# Altium schematic sheet Sch_mcu_brd_pwr.SchDoc
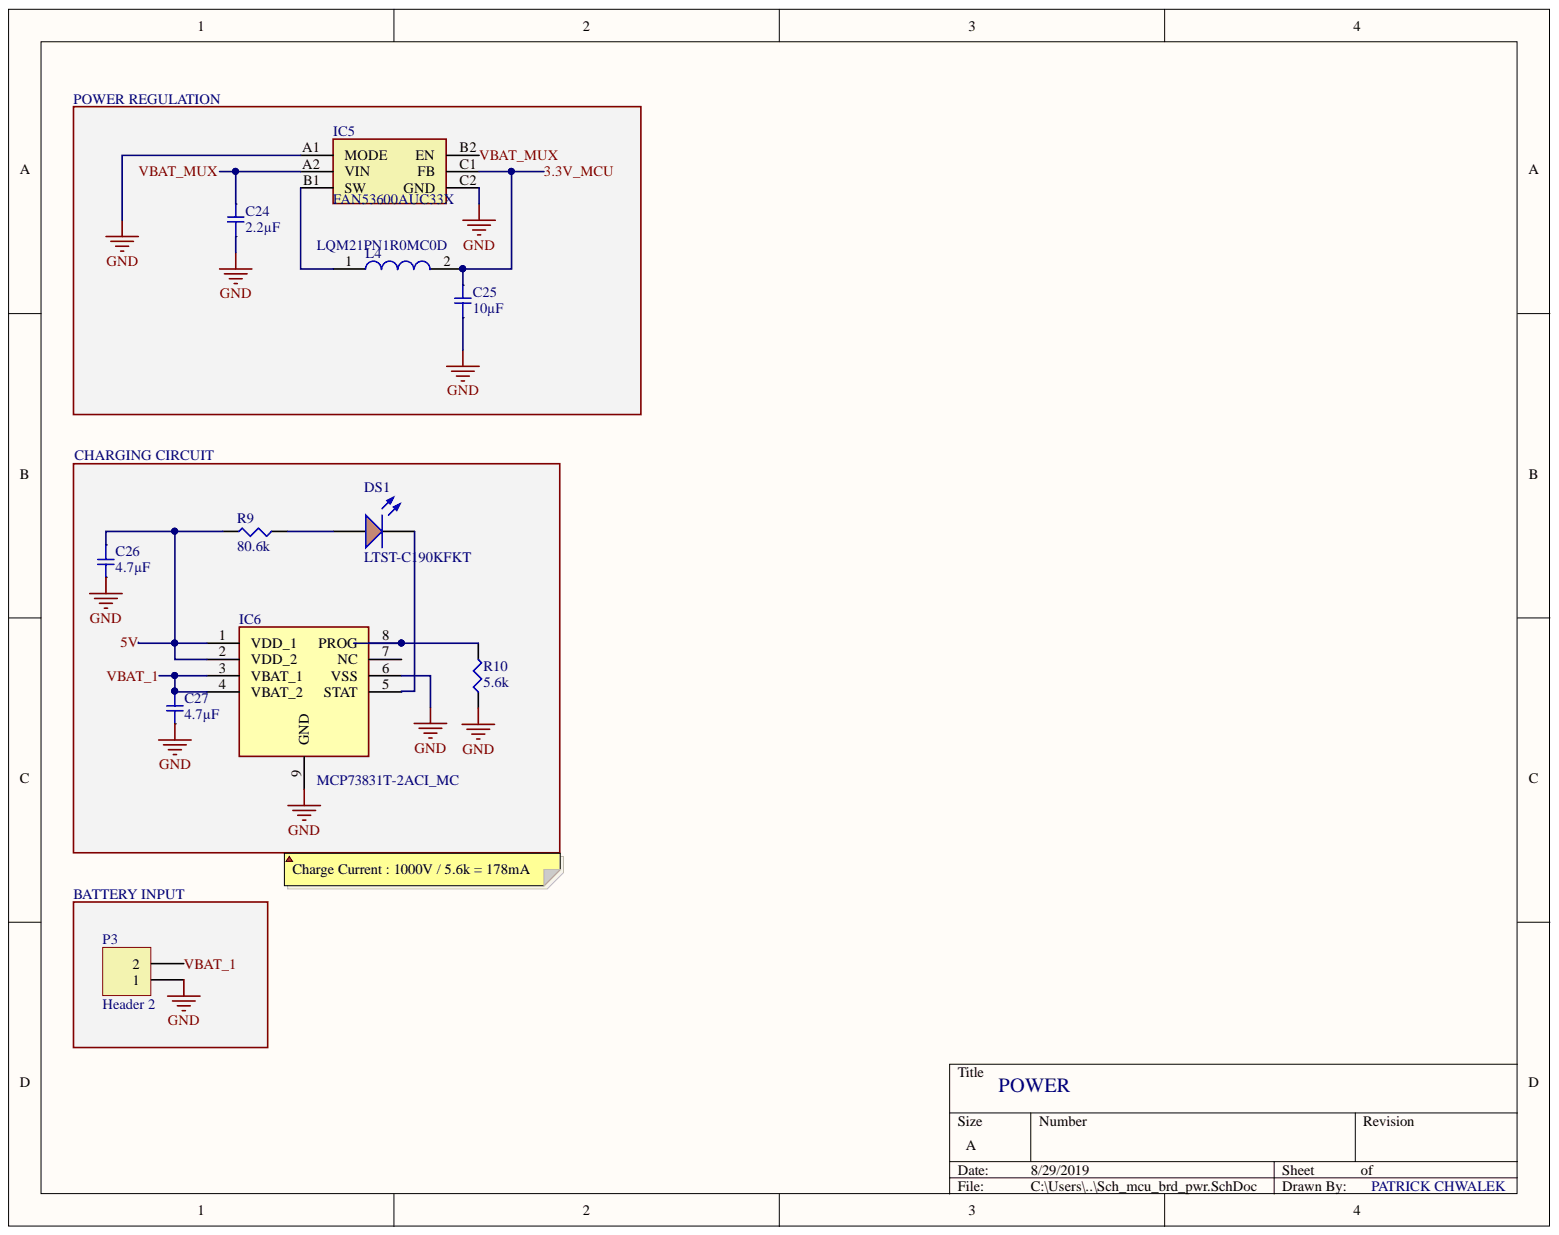
[diagram p0: Sch_mcu_brd_pwr.SchDoc]

## Parts
C24  2.2µF  [SMD-0402C]
C25  10µF  [SMD-0603C]
C26  4.7µF  [SMD-0402C]
C27  4.7µF  [SMD-0402C]
DS1  LTST-C190KFKT  [LTST-C190KFKT_V]
IC5  FAN53600AUC33X  [BGA6C40P2X3_86X116X62]
IC6  MCP73831T-2ACI_MC  [SON50P300X200X100-9N-D]
L4  LQM21PN1R0MC0D  [INDC2012X55N]
P3  Header 2  [HDR1X2]
R9  80.6k  [SMD-0402-RES]
R10  5.6k  [SMD-0402-RES]

## Nets
GND: C24.1 C25.1 C26.2 C27.2 IC5.A1(MODE) IC5.C2(GND) IC6.6(VSS) IC6.9(GND) P3.1 R10.1
3.3V_MCU: C25.2 IC5.C1(FB) L4.2
5V: C26.1 IC6.1(VDD_1) IC6.2(VDD_2) R9.2
VBAT_1: C27.1 IC6.3(VBAT_1) IC6.4(VBAT_2) P3.2
VBAT_MUX: C24.2 IC5.A2(VIN) IC5.B2(EN)
NetDS1_1: DS1.1 R9.1
NetDS1_2: DS1.2 IC6.5(STAT)
NetIC5_B1: IC5.B1(SW) L4.1
NetIC6_8: IC6.8(PROG) R10.2

Not connected: IC6 7(NC)

## Library data of the parts
C24 = GRM155R60J225ME15D: CAP CER 2.2UF 6.3V X5R 0402; Manufacturer: Murata Electronics North America; @ qty: 0; Minimum Quantity: 1; Tolerance: ±20%; Unit Price (USD): 0.15; Applications: General Purpose; Component Kind: Standard; Datasheets: http://www.murata.com/~/media/webrenewal/support/library/catalog/products/capacitor/mlcc/c02e.pdf; Digi-Key Part Number: 490-4519-1-ND; Factory Stock: 0; Features: Derating Recommended; Height - Seated (Max): -; Image: //media.digikey.com/Renders/Murata%20Renders/ZRB-SERIES-0402.jpg; Lead Spacing: -; Lead Style: -; Library Name: capacitor_murata_0402.IntLib; Mounting Type: Surface Mount, MLCC; Operating Temperature: -55°C ~ 85°C; Package / Case: 0402 (1005 Metric); Packaging: Cut Tape (CT); Part Number: GRM155R60J225ME15D; Part Status: Active; Quantity Available: 911621; Ratings: -; Series: GRM; Size / Dimension: 0.039" L x 0.020" W (1.00mm x 0.50mm); Temperature Coefficient: X5R; Thickness (Max): 0.022" (0.55mm); Value: 2.2µF; Voltage: 6.3V
C25 = GRM188R60J106ME47D: CAP CER 10UF 6.3V X5R 0603; Manufacturer: Murata Electronics North America; @ qty: 0; Minimum Quantity: 1; Tolerance: ±20%; Unit Price (USD): 0.15; Applications: General Purpose; Component Kind: Standard; Datasheets: http://www.murata.com/~/media/webrenewal/support/library/catalog/products/capacitor/mlcc/c02e.pdf; Digi-Key Part Number: 490-3896-1-ND; Factory Stock: 0; Features: -; Height - Seated (Max): -; Image: //media.digikey.com/Renders/Murata%20Renders/ZRB-SERIES-0402.jpg; Lead Spacing: -; Lead Style: -; Library Name: capacitor_murata_0603.IntLib; Mounting Type: Surface Mount, MLCC; Operating Temperature: -55°C ~ 85°C; Package / Case: 0603 (1608 Metric); Packaging: Cut Tape (CT); Part Number: GRM188R60J106ME47D; Part Status: Active; Quantity Available: 1578882; Ratings: -; Series: GRM; Size / Dimension: 0.063" L x 0.031" W (1.60mm x 0.80mm); Temperature Coefficient: X5R; Thickness (Max): 0.035" (0.90mm); Value: 10µF; Voltage: 6.3V
C26 C27 = GRM153R60G475ME15D: CAP CER 4.7UF 4V X5R 0402; Manufacturer: Murata Electronics North America; @ qty: 0; Minimum Quantity: 1; Tolerance: ±20%; Unit Price (USD): 0.27; Applications: General Purpose; Component Kind: Standard; Datasheets: http://psearch.en.murata.com/capacitor/product/GRM153R60G475ME15%23.pdf; Digi-Key Part Number: 490-13231-1-ND; Factory Stock: 0; Features: -; Height - Seated (Max): -; Image: //media.digikey.com/Renders/Murata%20Renders/ZRB-SERIES-0402.jpg; Lead Spacing: -; Lead Style: -; Library Name: capacitor_murata_0402.IntLib; Mounting Type: Surface Mount, MLCC; Operating Temperature: -55°C ~ 85°C; Package / Case: 0402 (1005 Metric); Packaging: Cut Tape (CT); Part Number: GRM153R60G475ME15D; Part Status: Active; Quantity Available: 9100; Ratings: -; Series: GRM; Size / Dimension: 0.039" L x 0.020" W (1.00mm x 0.50mm); Temperature Coefficient: X5R; Thickness (Max): 0.013" (0.33mm); Value: 4.7µF; Voltage: 4V
DS1 = CMP-1674-00008-1: LED Uni-Color Orange, 75 mW, 30 mA, -35 to 85 degC, 2-Pin SMD, RoHS, Tape and Reel; Case\Package: 0603; Power Rating: 100 mW; Resistance: 47 kohm; Tolerance: 1%; Voltage Rating: 50 V; Case Code (Imperial): 0603; Case Code (Metric): 1608; Component Kind: Standard; ComponentLink1Description: Manufacturer URL; ComponentLink1URL: http://www.us.liteon.com/; ComponentLink2Description: Datasheet; ComponentLink2URL: http://optoelectronics.liteon.com/upload/download/DS-22-99-0186/S_110_LTST-C190KFKT.pdf; DatasheetVersion: Rev. I, 09/2011; Height: 0.45 mm; Length: 1.6 mm; Library Name: Altium Content Vault; Max Operating Temperature: 155 degC; Min Operating Temperature: -55 degC; Mount: Surface Mount; PackageDescription: 2-Pin Surface Mount Device, Body 1.6 x 0.8 mm; Package Quantity: 5000; PackageReference: C190KFKT; Packaging: Tape and Reel; Pins: 2; REACH SVHC: No SVHC; RoHSCompliant: true; Voltage Rating (DC): 50 V; Width: 0.8 mm
IC5 = FAN53600AUC33X: Integrated Circuit; Manufacturer_Name: Fairchild Semiconductor; Component Kind: Standard; Datasheet Link: https://www.onsemi.com/pub/Collateral/FAN53610-D.pdf; Height: 0.625mm; Library Name: SamacSys.SchLib; Manufacturer_Part_Number: FAN53600AUC33X; Mouser Part Number: 512-FAN53600AUC33X; Mouser Price/Stock: https://www.mouser.com/Search/Refine.aspx?Keyword=512-FAN53600AUC33X
IC6 = MCP73831T-2ACI_MC: Integrated Circuit; Manufacturer_Name: Microchip; Manufacturer_Part_Number: MCP73831T-2ACI/MC; Allied_Number: 70452042; Allied Price/Stock: https://www.alliedelec.com/microchiptechnologyinc-mcp73831t-2aci-mc/70452042/; Component Kind: Standard; Datasheet Link: http://ww1.microchip.com/downloads/en/DeviceDoc/20001984g.pdf; Height: 1mm; Library Name: SamacSys.SchLib; Mouser Part Number: 579-MCP73831T-2ACIMC; Mouser Price/Stock: https://www.mouser.com/Search/Refine.aspx?Keyword=579-MCP73831T-2ACIMC
L4 = LQM21PN1R0MC0D: Inductor; Manufacturer_Name: Murata Electronics; Component Kind: Standard; Datasheet Link: https://componentsearchengine.com/Datasheets/2/LQM21PN1R0MC0D.pdf; Height: 0.55mm; Library Name: SamacSys.SchLib; Manufacturer_Part_Number: LQM21PN1R0MC0D; Mouser Part Number: 81-LQM21PN1R0MC0D; Mouser Price/Stock: https://www.mouser.com/Search/Refine.aspx?Keyword=81-LQM21PN1R0MC0D; RS Part Number: 7926388; RS Price/Stock: https://uk.rs-online.com/web/p/products/7926388
P3 = Header 2: Header, 2-Pin; Component Kind: Standard; LatestRevisionDate: 17-Jul-2002; LatestRevisionNote: Re-released for DXP Platform.; Library Name: Miscellaneous Connectors.IntLib; Signal Integrity: Connector; Publisher: Altium Limited
R9 = ERJ-2RKF8062X: RES SMD 80.6K OHM 1% 1/10W 0402; Manufacturer: Panasonic Electronic Components; @ qty: 1500; Factory Stock: 0; Minimum Quantity: 1; Power (Watts): 0.1W, 1/10W; Temperature Coefficient: ±100ppm/°C; Unit Price (USD): 0.00496; Component Kind: Standard; Composition: Thick Film; Datasheets: http://industrial.panasonic.com/www-cgi/jvcr13pz.cgi?E+PZ+3+AOA0002+ERJ2RKF8062X+7+WW; Digi-Key Part Number: P80.6KLCT-ND; Failure Rate: -; Features: Automotive AEC-Q200; Height - Seated (Max): 0.016" (0.40mm); Image: //media.digikey.com/Photos/Panasonic%20Photos/ERJ-PA2-Series.jpg; Library Name: Panasonic_ERJ_0402.IntLib; Number of Terminations: 2; Operating Temperature: -55°C ~ 155°C; Package / Case: 0402 (1005 Metric); Packaging: Cut Tape (CT); Part Number: ERJ-2RKF8062X; Part Status: Active; Quantity Available: 91747; Series: ERJ; Size / Dimension: 0.039" L x 0.020" W (1.00mm x 0.50mm); Supplier Device Package: 0402; Tolerance: ±1%; Value: 80.6k
R10 = ERJ-2GEJ562X: RES SMD 5.6K OHM 5% 1/10W 0402; Manufacturer: Panasonic Electronic Components; @ qty: 1500; Factory Stock: 0; Minimum Quantity: 1; Power (Watts): 0.1W, 1/10W; Temperature Coefficient: ±200ppm/°C; Unit Price (USD): 0.00350; Component Kind: Standard; Composition: Thick Film; Datasheets: http://industrial.panasonic.com/www-cgi/jvcr13pz.cgi?E+PZ+3+AOA0001+ERJ2GEJ562X+7+WW; Digi-Key Part Number: P5.6KJCT-ND; Failure Rate: -; Features: Automotive AEC-Q200; Height - Seated (Max): 0.016" (0.40mm); Image: //media.digikey.com/Photos/Panasonic%20Photos/ERJ-PA2-Series.jpg; Library Name: Panasonic_ERJ_0402.IntLib; Number of Terminations: 2; Operating Temperature: -55°C ~ 155°C; Package / Case: 0402 (1005 Metric); Packaging: Cut Tape (CT); Part Number: ERJ-2GEJ562X; Part Status: Active; Quantity Available: 212112; Series: ERJ; Size / Dimension: 0.039" L x 0.020" W (1.00mm x 0.50mm); Supplier Device Package: 0402; Tolerance: ±5%; Value: 5.6k
